# Altium schematic sheet 02_CPU.SchDoc
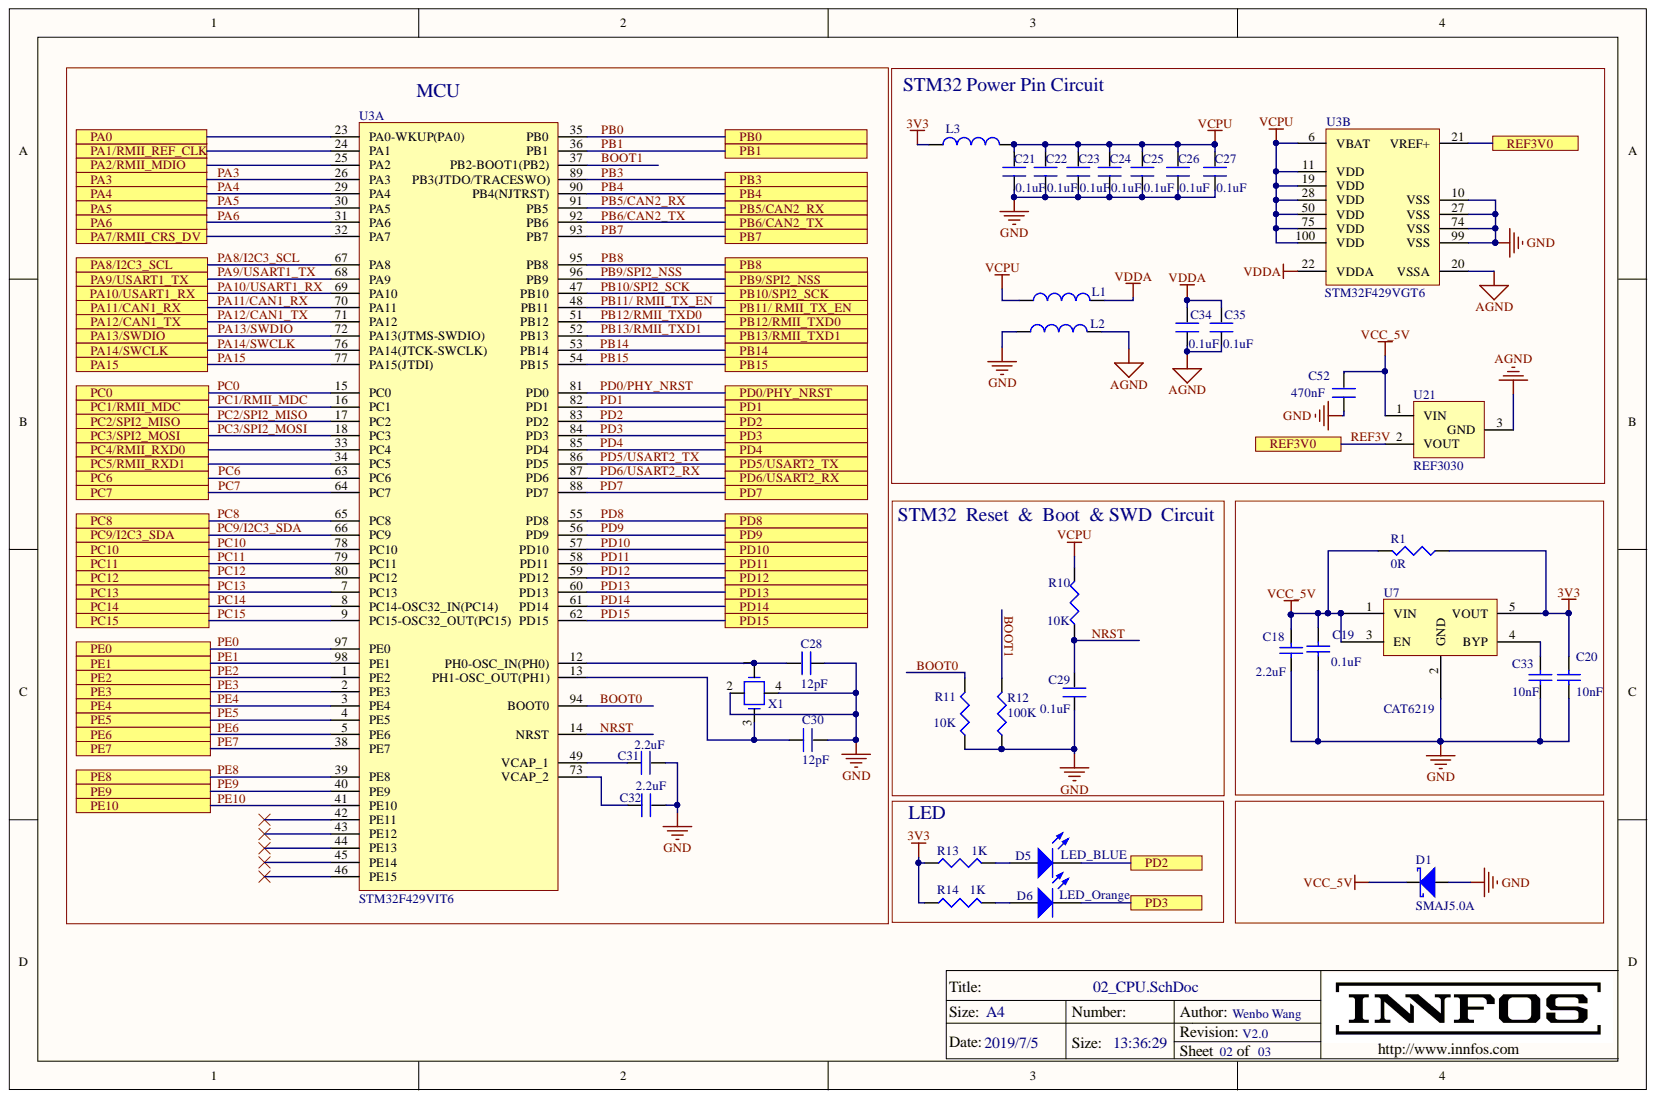
[diagram p0: 02_CPU.SchDoc]

## Parts
C18  2.2uF  [0402]
C19  0.1uF  [0402]
C20  10nF  [0402]
C21  0.1uF  [0402]
C22  0.1uF  [0402]
C23  0.1uF  [0402]
C24  0.1uF  [0402]
C25  0.1uF  [0402]
C26  0.1uF  [0402]
C27  0.1uF  [0402]
C28  12pF  [0402]
C29  0.1uF  [0402]
C30  12pF  [0402]
C31  2.2uF  [0402]
C32  2.2uF  [0402]
C33  10nF  [0402]
C34  0.1uF  [0402]
C35  0.1uF  [0402]
C52  470nF  [0402]
D1  SMAJ5.0A  [SMA_DO_214AC]
D5  LED_BLUE  [LED_0603_BLUE]
D6  LED_Orange  [LED_0603_ORG]
L1  BLM15PG100SN1  [0402]  (value not drawn)
L2  BLM15PG100SN1  [0402]  (value not drawn)
L3  BLM15PG100SN1  [0402]  (value not drawn)
R1  0R  [0603]  (no BOM)
R10  10K  [0402]
R11  10K  [0402]
R12  100K  [0402]
R13  1K  [0402]
R14  1K  [0402]
U3  STM32F429VIT6  PartNumber: STM32F429VGT6  [LC-LQFP-100_14x14x05P]  (parts A,B)
U7  CAT6219  [SOT23_5]
U21  REF3030  [SOT23_3]
X1  XTAL(8M)  [X1_3225]  (value not drawn)

## Ports
PA0, PA1/RMII_REF_CLK, PA2/RMII_MDIO, PA3, PA4, PA5, PA6, PA7/RMII_CRS_DV, PA8/I2C3_SCL, PA9/USART1_TX, PA10/USART1_RX, PA11/CAN1_RX, PA12/CAN1_TX, PA13/SWDIO, PA14/SWCLK, PA15, PB0, PB1, PB3, PB4, PB5/CAN2_RX, PB6/CAN2_TX, PB7, PB8, PB9/SPI2_NSS, PB10/SPI2_SCK, PB11/ RMII_TX_EN, PB12/RMII_TXD0, PB13/RMII_TXD1, PB14, PB15, PC0, PC1/RMII_MDC, PC2/SPI2_MISO, PC3/SPI2_MOSI, PC4/RMII_RXD0, PC5/RMII_RXD1, PC6, PC7, PC8, PC9/I2C3_SDA, PC10, PC11, PC12, PC13, PC14, PC15, PD0/PHY_NRST, PD1, PD2, PD3, PD4, PD5/USART2_TX, PD6/USART2_RX, PD7, PD8, PD9, PD10, PD11, PD12, PD13, PD14, PD15, PE0, PE1, PE2, PE3, PE4, PE5, PE6, PE7, PE8, PE9, PE10, REF3V0

## Nets
3V3: C20.2 L3.1 R1.1 R13.1 R14.1 U7.5(VOUT)
AGND: C34.1 C35.1 L2.2 U3.20(VSSA) U21.3
GND: C18.1 C19.1 C20.1 C21.1 C22.1 C23.1 C24.1 C25.1 C26.1 C27.1 C28.1 C29.1 C30.2 C31.2 C32.2 C33.1 C52.1 D1.1 L2.1 R11.1 R12.1 U3.10(VSS) U3.27(VSS) U3.74(VSS) U3.99(VSS) U7.2(GND) X1.2 X1.4
VCC_5V: C18.2 C19.2 C52.2 D1.2 R1.2 U7.1(VIN) U7.3(EN) U21.1
VCPU: C21.2 C22.2 C23.2 C24.2 C25.2 C26.2 C27.2 L1.1 L3.2 R10.2 U3.6(VBAT) U3.11(VDD) U3.19(VDD) U3.28(VDD) U3.50(VDD) U3.75(VDD) U3.100(VDD)
VDDA: C34.2 C35.2 L1.2 U3.22(VDDA)
BOOT0: R11.2 U3.94(BOOT0)
BOOT1: R12.2 U3.37(PB2-BOOT1(PB2))
NRST: C29.2 R10.1 U3.14(NRST)
PA0: U3.23(PA0-WKUP(PA0)) ; port PA0
PA1/RMII_REF_CLK: U3.24(PA1) ; port PA1/RMII_REF_CLK
PA2/RMII_MDIO: U3.25(PA2) ; port PA2/RMII_MDIO
PA3: U3.26(PA3) ; port PA3
PA4: U3.29(PA4) ; port PA4
PA5: U3.30(PA5) ; port PA5
PA6: U3.31(PA6) ; port PA6
PA7/RMII_CRS_DV: U3.32(PA7) ; port PA7/RMII_CRS_DV
PA8/I2C3_SCL: U3.67(PA8) ; port PA8/I2C3_SCL
PA9/USART1_TX: U3.68(PA9) ; port PA9/USART1_TX
PA10/USART1_RX: U3.69(PA10) ; port PA10/USART1_RX
PA11/CAN1_RX: U3.70(PA11) ; port PA11/CAN1_RX
PA12/CAN1_TX: U3.71(PA12) ; port PA12/CAN1_TX
PA13/SWDIO: U3.72(PA13(JTMS-SWDIO)) ; port PA13/SWDIO
PA14/SWCLK: U3.76(PA14(JTCK-SWCLK)) ; port PA14/SWCLK
PA15: U3.77(PA15(JTDI)) ; port PA15
PB0: U3.35(PB0) ; port PB0
PB1: U3.36(PB1) ; port PB1
PB3: U3.89(PB3(JTDO/TRACESWO)) ; port PB3
PB4: U3.90(PB4(NJTRST)) ; port PB4
PB5/CAN2_RX: U3.91(PB5) ; port PB5/CAN2_RX
PB6/CAN2_TX: U3.92(PB6) ; port PB6/CAN2_TX
PB7: U3.93(PB7) ; port PB7
PB8: U3.95(PB8) ; port PB8
PB9/SPI2_NSS: U3.96(PB9) ; port PB9/SPI2_NSS
PB10/SPI2_SCK: U3.47(PB10) ; port PB10/SPI2_SCK
PB11/ RMII_TX_EN: U3.48(PB11) ; port PB11/ RMII_TX_EN
PB12/RMII_TXD0: U3.51(PB12) ; port PB12/RMII_TXD0
PB13/RMII_TXD1: U3.52(PB13) ; port PB13/RMII_TXD1
PB14: U3.53(PB14) ; port PB14
PB15: U3.54(PB15) ; port PB15
PC0: U3.15(PC0) ; port PC0
PC1/RMII_MDC: U3.16(PC1) ; port PC1/RMII_MDC
PC2/SPI2_MISO: U3.17(PC2) ; port PC2/SPI2_MISO
PC3/SPI2_MOSI: U3.18(PC3) ; port PC3/SPI2_MOSI
PC4/RMII_RXD0: U3.33(PC4) ; port PC4/RMII_RXD0
PC5/RMII_RXD1: U3.34(PC5) ; port PC5/RMII_RXD1
PC6: U3.63(PC6) ; port PC6
PC7: U3.64(PC7) ; port PC7
PC8: U3.65(PC8) ; port PC8
PC9/I2C3_SDA: U3.66(PC9) ; port PC9/I2C3_SDA
PC10: U3.78(PC10) ; port PC10
PC11: U3.79(PC11) ; port PC11
PC12: U3.80(PC12) ; port PC12
PC13: U3.7(PC13) ; port PC13
PC14: U3.8(PC14-OSC32_IN(PC14)) ; port PC14
PC15: U3.9(PC15-OSC32_OUT(PC15)) ; port PC15
PD0/PHY_NRST: U3.81(PD0) ; port PD0/PHY_NRST
PD1: U3.82(PD1) ; port PD1
PD2: D5.2 U3.83(PD2) ; port PD2
PD3: D6.2 U3.84(PD3) ; port PD3
PD4: U3.85(PD4) ; port PD4
PD5/USART2_TX: U3.86(PD5) ; port PD5/USART2_TX
PD6/USART2_RX: U3.87(PD6) ; port PD6/USART2_RX
PD7: U3.88(PD7) ; port PD7
PD8: U3.55(PD8) ; port PD8
PD9: U3.56(PD9) ; port PD9
PD10: U3.57(PD10) ; port PD10
PD11: U3.58(PD11) ; port PD11
PD12: U3.59(PD12) ; port PD12
PD13: U3.60(PD13) ; port PD13
PD14: U3.61(PD14) ; port PD14
PD15: U3.62(PD15) ; port PD15
PE0: U3.97(PE0) ; port PE0
PE1: U3.98(PE1) ; port PE1
PE2: U3.1(PE2) ; port PE2
PE3: U3.2(PE3) ; port PE3
PE4: U3.3(PE4) ; port PE4
PE5: U3.4(PE5) ; port PE5
PE6: U3.5(PE6) ; port PE6
PE7: U3.38(PE7) ; port PE7
PE8: U3.39(PE8) ; port PE8
PE9: U3.40(PE9) ; port PE9
PE10: U3.41(PE10) ; port PE10
REF3V: U3.21(VREF+) U21.2 ; port REF3V0
NetC28_2: C28.2 U3.12(PH0-OSC_IN(PH0)) X1.1
NetC30_1: C30.1 U3.13(PH1-OSC_OUT(PH1)) X1.3
NetC31_1: C31.1 U3.49(VCAP_1)
NetC32_1: C32.1 U3.73(VCAP_2)
NetC33_2: C33.2 U7.4(BYP)
NetD5_1: D5.1 R13.2
NetD6_1: D6.1 R14.2

Not connected: U3 42(PE11) 43(PE12) 44(PE13) 45(PE14) 46(PE15)

## Library data of the parts
C18 = Cap: Capacitor; Value: 10uf; Component Kind: Standard; LatestRevisionDate: 17-Jul-2002; LatestRevisionNote: Re-released for DXP Platform.; Library Name: Miscellaneous Devices.IntLib; PackageDescription: Radial Cap, Thru-Hole; 2 Leads; 0.3 in Pin Spacing; PackageReference: RAD-0.3; Published: 8-Jun-2000; Publisher: Altium Limited
C19 = Cap: Capacitor; Value: 100nF; Component Kind: Standard; LatestRevisionDate: 17-Jul-2002; LatestRevisionNote: Re-released for DXP Platform.; Library Name: Miscellaneous Devices.IntLib; PackageDescription: Radial Cap, Thru-Hole; 2 Leads; 0.3 in Pin Spacing; PackageReference: RAD-0.3; Published: 8-Jun-2000; Publisher: Altium Limited
C20 C33 = Cap: Capacitor; Component Kind: Standard; LatestRevisionDate: 17-Jul-2002; LatestRevisionNote: Re-released for DXP Platform.; Library Name: Miscellaneous Devices.IntLib; PackageDescription: Radial Cap, Thru-Hole; 2 Leads; 0.3 in Pin Spacing; PackageReference: RAD-0.3; Published: 8-Jun-2000; Value: 10nF; Publisher: Altium Limited
C21-C32 C34 C35 = Cap: Component Kind: Standard; Library Name: My_Schlib.SchLib
  C21-C27 C29 C34 C35: Value: 0.1uF
  C28 C30: Value: 12pF
  C31 C32: Value: 2.2uF
C52 = Cap: Value: 1uF; Component Kind: Standard; Library Name: MY_INTEGRATED_LIBRARY.IntLib
D1 = Diode BAT17: Silicon RF Schottky Diode for Mixer Applications in the VHF/UHF Range; Note: Pin 2 is not connected and not drawn.; Component Kind: Standard; ComponentLink1Description: Manufacturer Link; ComponentLink1URL: http://www.infineon.com/; ComponentLink2Description: Datasheet; ComponentLink2URL: http://www.infineon.com/upload/Document/cmc_upload/documents/009/363/bat17series.pdf; DatasheetVersion: Oct-1999; LatestRevisionDate: 29-May-2009; LatestRevisionNote: IPC-7351 Footprint Added.; Library Name: Miscellaneous Devices.IntLib; PackageDescription: 3-Pin SOT-23 Package 0.95 mm Pitch, 2.5 mm Lead Span; PackageReference: SOT-23; PackageVersion: Sep-2001; Published: 21-Mar-2003
D5 D6 = LED(0603): Component Kind: Standard; Library Name: MY_INTEGRATED_LIBRARY.IntLib
L1-L3 = Inductor: OET'S LIB; Code_IEC: 1005; Code_JEITA: 0402; Component Kind: Standard; LatestRevisionDate: 17-Jul-2002; LatestRevisionNote: Re-released for DXP Platform.; Library Name: Miscellaneous Devices.IntLib; PackageReference: 0402-A; Published: 8-Jun-2000; Simulation: INDUCTOR; Publisher: Altium Limited
R1 R12 = Res: Value: 10K; Library Name: My_Schlib.SchLib
  R12: Component Kind: Standard; PCB3D: Model Name
R10 R11 R13 R14 = Res: Component Kind: Standard
  R10 R11: Library Name: My_Schlib.SchLib; PCB3D: Model Name; Value: 10K
  R13 R14: Library Name: MY_INTEGRATED_LIBRARY.IntLib
U3 = STM32F407VGT6: ARM Cortex-M4 32-bit MCU+FPU, 210 DMIPS, 1024 kB Flash, 192 kB Internal RAM, 82 I/Os, 100-pin LQFP, -40 to 85 degC, Tray; Manufacturer: STMicroelectronics; D/A Converter: 2x12-bit; FLASH Size (Prog) (kB): 1024; I/Os (High Current): 82; Internal RAM Size (kB): 192; Operating Frequency(F) (Processor speed) (MHz): 168; Supply Voltage(Vcc) max (V): 3.6; Supply Voltage(Vcc) min (V): 1.8; Component Kind: Standard; ComponentLink1Description: Manufacturer URL; ComponentLink1URL: http://www.st.com/web/en/home.html; ComponentLink2Description: Datasheet; ComponentLink2URL: http://www.st.com/st-web-ui/static/active/en/resource/technical/document/datasheet/DM00037051.pdf; ComponentLink3Description: Package Specification; ComponentLink3URL: http://www.st.com/st-web-ui/static/active/en/resource/technical/document/data_brief/DM00071938.pdf; Core: ARM Cortex-M4; DatasheetVersion: Rev. 4; Library Name: STMicroelectronics STM32 F4.SchLib; Mounting Technology: Surface Mount; PackageDescription: 100-Pin Low Profile Quad Flat Package, 14 x 14 mm Body, 0.5 mm Pitch; PackageReference: LQFP100; PackageVersion: Rev. 1, 06/2013; Packing: Tray; Supplier 1: Digi-Key; Supplier 2: Farnell; Supplier 3: Newark; Supplier 4: Mouser; Supplier Part Number 1: 497-11605-ND; Supplier Part Number 2: 2064368; Supplier Part Number 3: 73T2333; Supplier Part Number 4: 511-STM32F429VGT6
U21 = REF30**: Component Kind: Standard; Library Name: My_Schlib.SchLib
X1 = XTAL(5032_4PADS): Component Kind: Standard; Library Name: My_Schlib.SchLib
U7 = CAT6219: Component Kind: Standard; Library Name: My_Schlib.SchLib
